# Altium schematic sheet External_Connectors.SchDoc(External_Connectors)
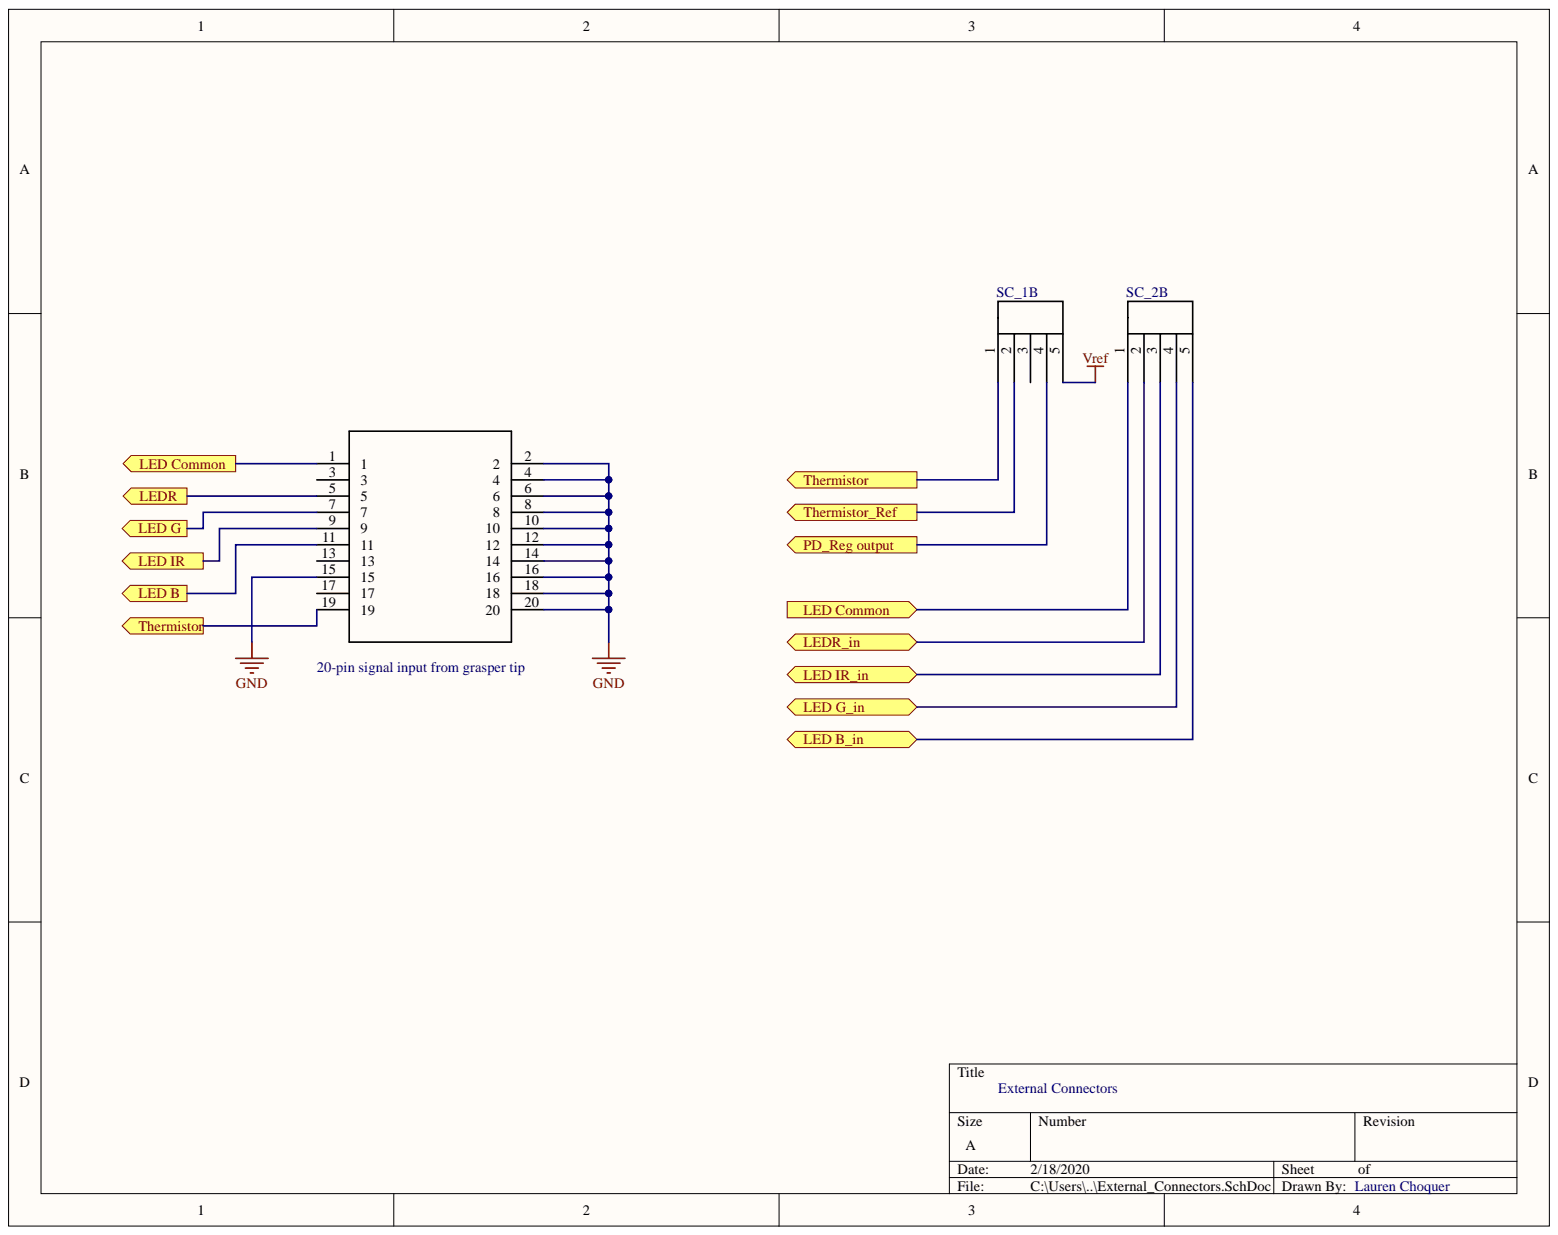
[diagram p0: External_Connectors.SchDoc]

## Parts
20-pin  N2520-6V0C-RB-WE  [*3M_N2520-6V0C-RB-WE]  (value not drawn)
SC_1  Component_1  [PCBComponent_1]  (value not drawn)  (part B)
SC_2  Component_1  [PCBComponent_1]  (value not drawn)  (part B)

## Ports
LED B, LED B_in, LED Common, LED G, LED G_in, LED IR, LED IR_in, LEDR, LEDR_in, PD_Reg output, Thermistor, Thermistor_Ref

## Nets
GND: 20-pin.2 20-pin.4 20-pin.6 20-pin.8 20-pin.10 20-pin.12 20-pin.14 20-pin.15 20-pin.16 20-pin.18 20-pin.20
Vref: SC_1.5
LED B: 20-pin.11 ; port LED B
LED B_in: SC_2.5 ; port LED B_in
LED Common: 20-pin.1 SC_2.1 ; port LED Common
LED G: 20-pin.7 ; port LED G
LED G_in: SC_2.4 ; port LED G_in
LED IR: 20-pin.9 ; port LED IR
LED IR_in: SC_2.3 ; port LED IR_in
LEDR: 20-pin.5 ; port LEDR
LEDR_in: SC_2.2 ; port LEDR_in
PD_Reg output: SC_1.4 ; port PD_Reg output
Thermistor: 20-pin.19 SC_1.1 ; port Thermistor
Thermistor_Ref: SC_1.2 ; port Thermistor_Ref

Not connected: 20-pin 3 13 17; SC_1 3

## Library data of the parts
20-pin = N2520-6V0C-RB-WE: 2500 Series Low Profile SMT 4 wall Header, Straight, .100 X .100 20 Position; MF: 3M; SnapEDA_Link: https://www.snapeda.com/parts/N2520-6V0C-RB-WE/3M%20%28TC%29/view-part/?ref=snap; Package: None; MP: N2520-6V0C-RB-WE; Library Name: N2520-6V0C-RB-WE.SchLib; Digi-Key_Purchase_URL: https://www.digikey.com/product-detail/en/3m/N2520-6V0C-RB-WE/MSH20KCT-ND/1125449?utm_source=snapeda&utm_medium=aggregator&utm_campaign=symbol; Digi-Key_Part_Number: MSH20KCT-ND; Component Kind: Standard
SC_1 SC_2 = Component_1: Library Name: ScrewConn_V2.IntLib; Component Kind: Standard
